# Altium schematic sheet 06 AFE&ADC Power.SchDoc
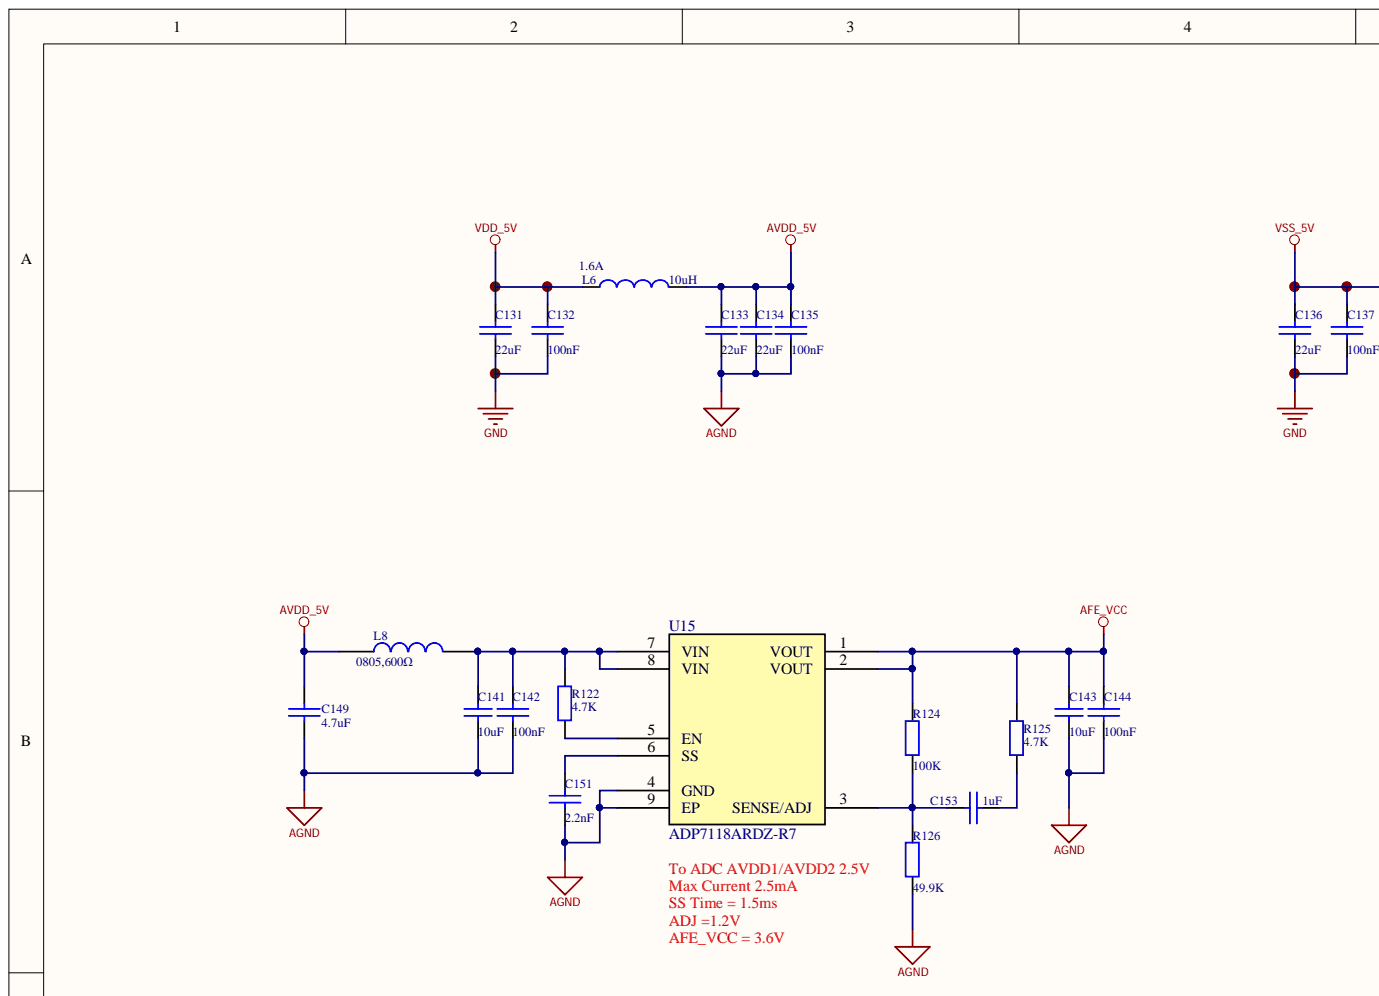
[diagram p0: 06 AFE&ADC Power.SchDoc, top left]
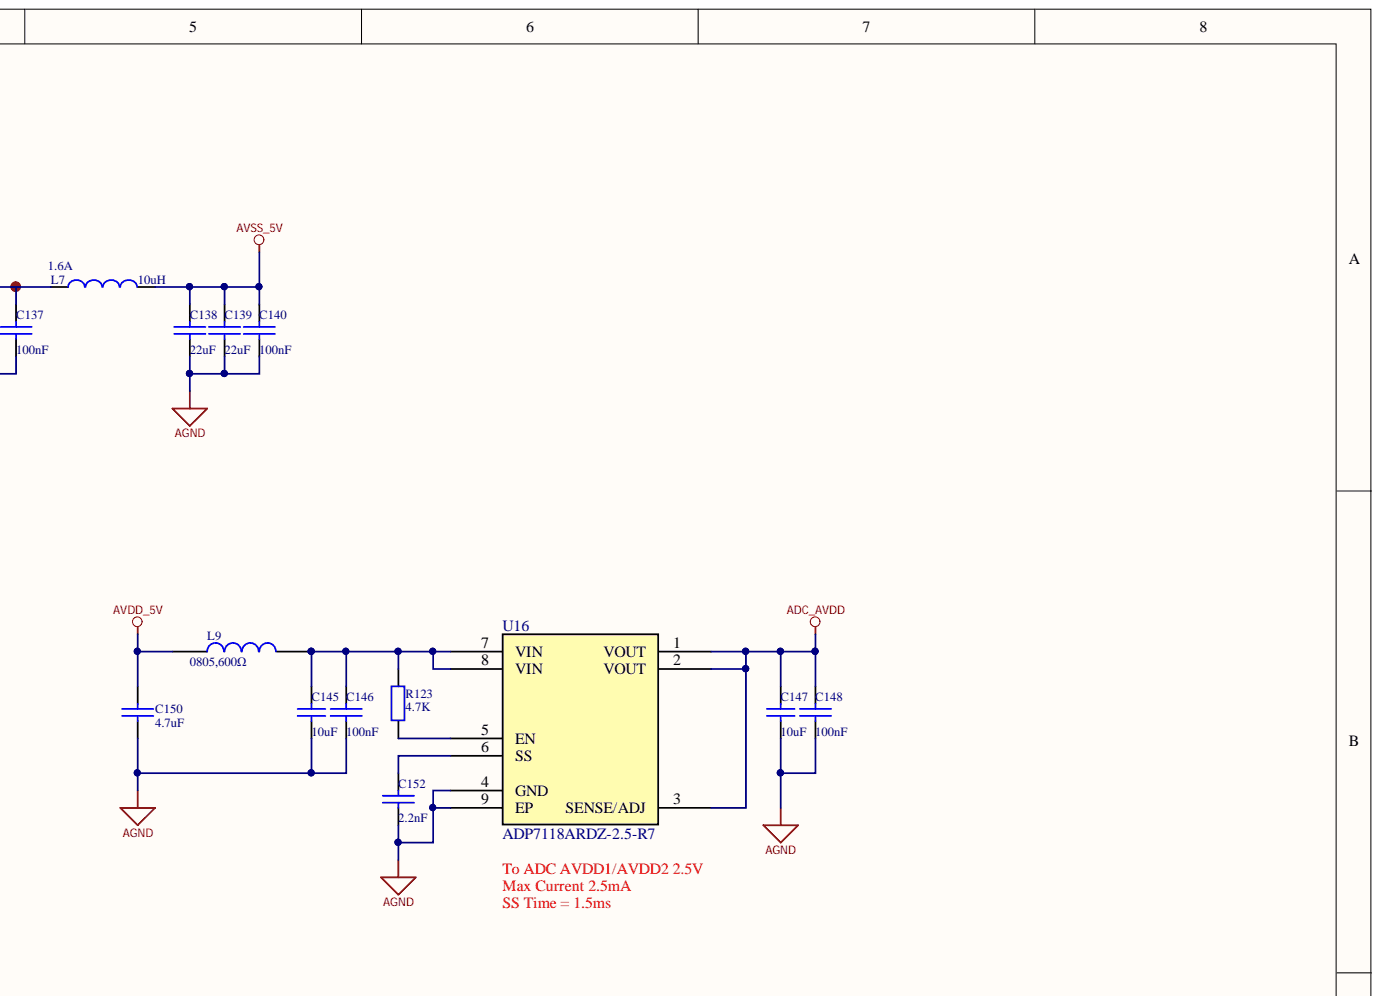
[diagram p1: 06 AFE&ADC Power.SchDoc, top right]
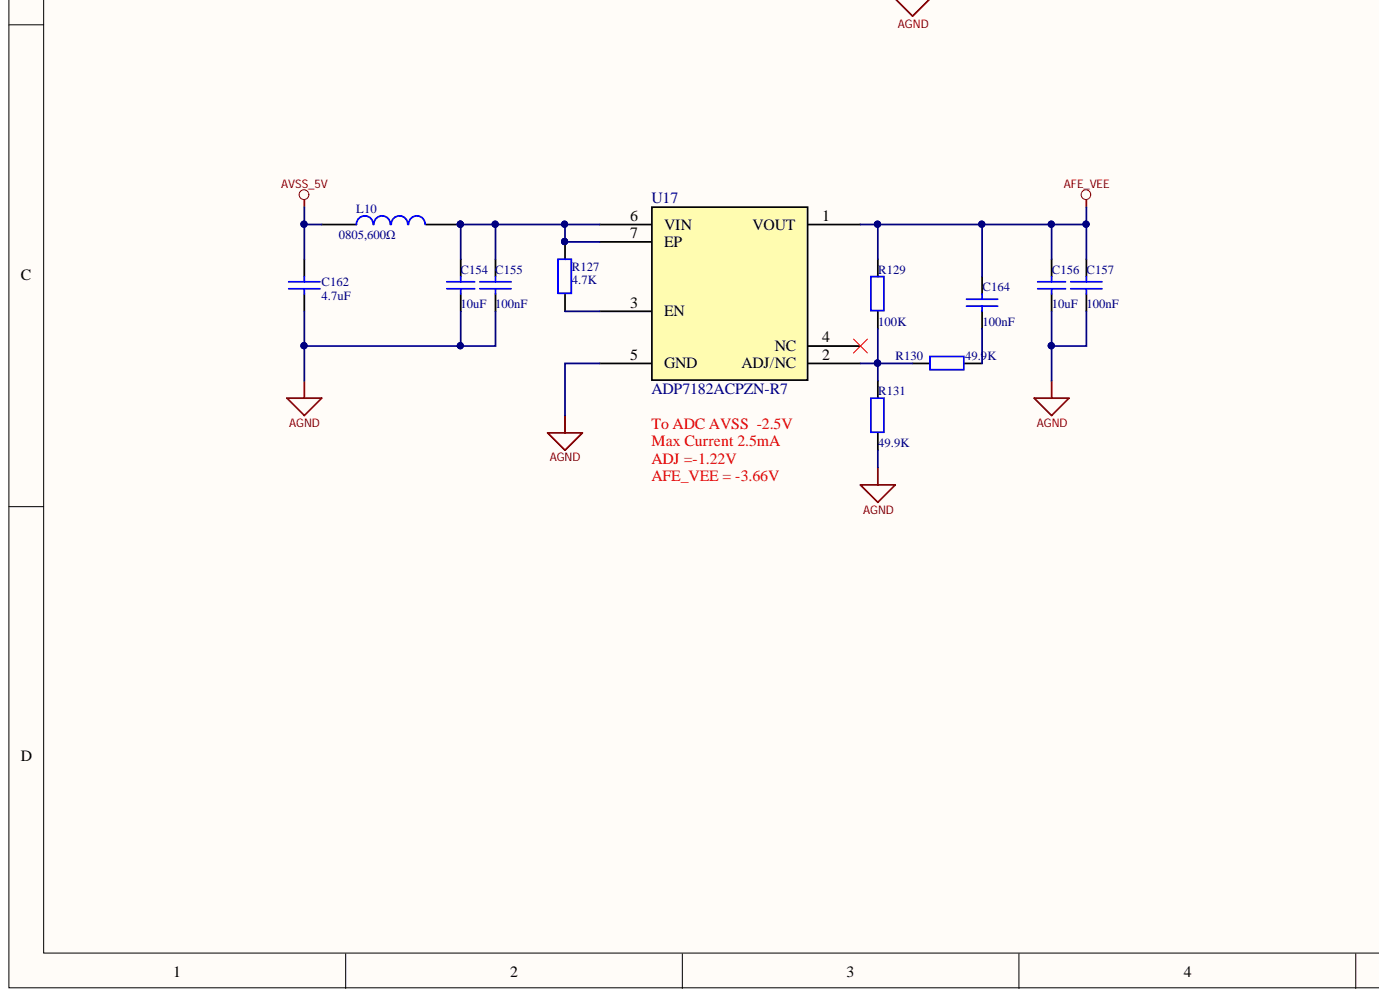
[diagram p2: 06 AFE&ADC Power.SchDoc, bottom left]
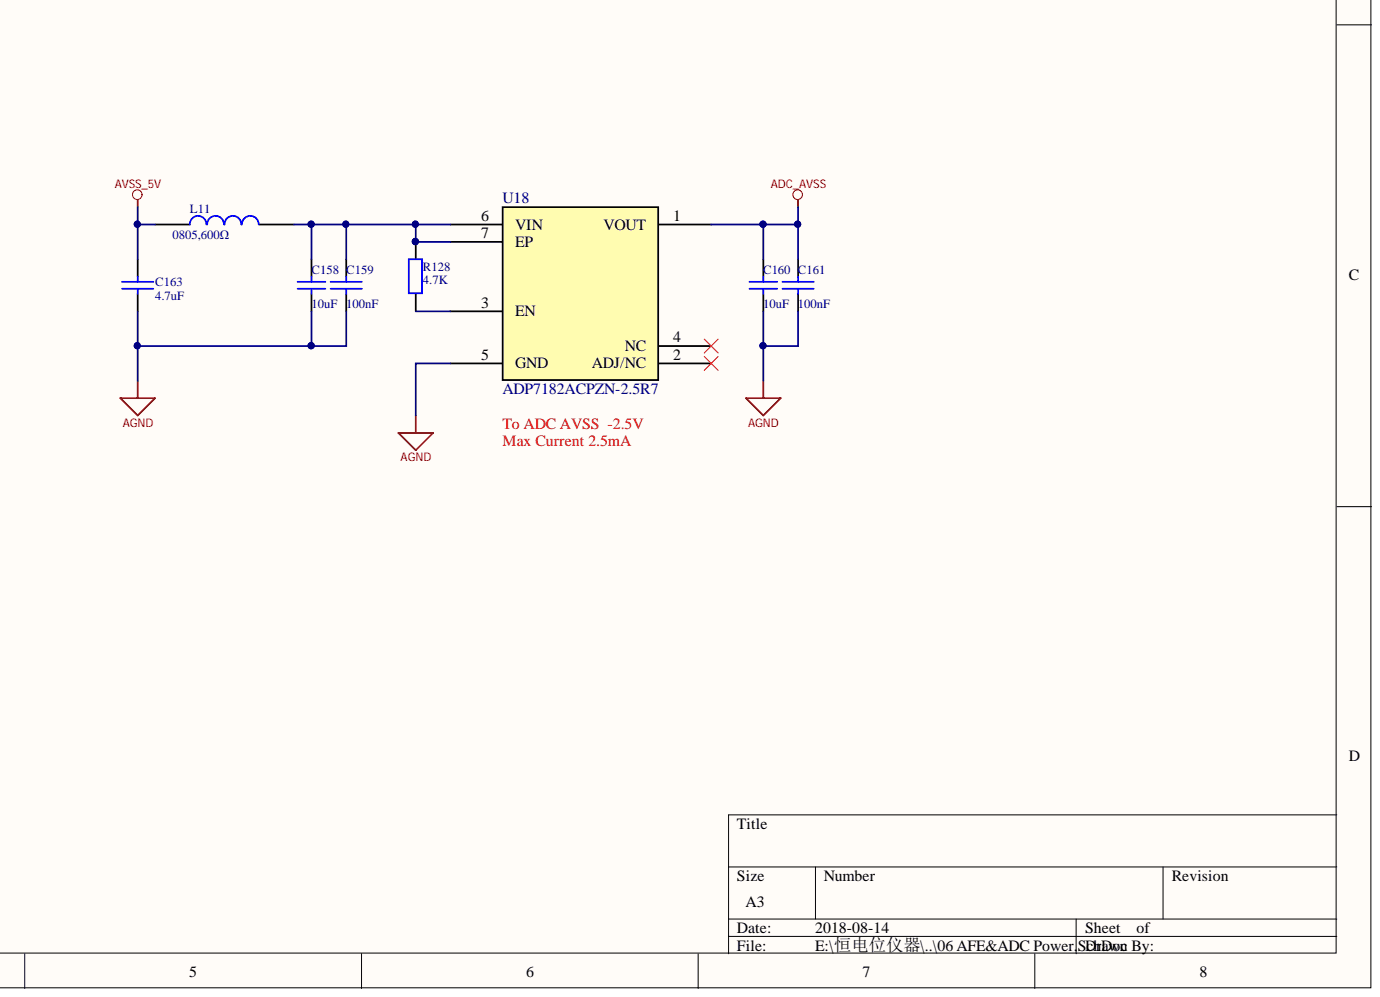
[diagram p3: 06 AFE&ADC Power.SchDoc, bottom right]

## Parts
C131  22uF  [SC0603]
C132  100nF  [SC0402]
C133  22uF  [SC0603]
C134  22uF  [SC0603]
C135  100nF  [SC0402]
C136  22uF  [SC0603]
C137  100nF  [SC0402]
C138  22uF  [SC0603]
C139  22uF  [SC0603]
C140  100nF  [SC0402]
C141  10uF  [SC0805]
C142  100nF  [SC0603]
C143  10uF  [SC0805]
C144  100nF  [SC0402]
C145  10uF  [SC0805]
C146  100nF  [SC0603]
C147  10uF  [SC0805]
C148  100nF  [SC0402]
C149  4.7uF  [SC0603]
C150  4.7uF  [SC0603]
C151  2.2nF  [SC0603]
C152  2.2nF  [SC0603]
C153  1uF  [SC0402]
C154  10uF  [SC0805]
C155  100nF  [SC0603]
C156  10uF  [SC0805]
C157  100nF  [SC0402]
C158  10uF  [SC0805]
C159  100nF  [SC0603]
C160  10uF  [SC0805]
C161  100nF  [SC0402]
C162  4.7uF  [SC0603]
C163  4.7uF  [SC0603]
C164  100nF  [SC0402]
L6  value 10uH  Saturation Current: 1.6A  [HSL4020]  (hidden comment: 终端片式电感-10uH-+/-20%-0.9A-0.215OHM-4.0*2.0)
L7  value 10uH  Saturation Current: 1.6A  [HSL4020]  (hidden comment: 终端片式电感-10uH-+/-20%-0.9A-0.215OHM-4.0*2.0)
L8  0805,600Ω  [SL0805]
L9  0805,600Ω  [SL0805]
L10  0805,600Ω  [SL0805]
L11  0805,600Ω  [SL0805]
R122  value 4.7K  [SR0402]  (hidden comment: 4.7K±1%)
R123  value 4.7K  [SR0402]  (hidden comment: 4.7K±1%)
R124  value 100K  [SR0402]  (hidden comment: 100K±1%)
R125  value 4.7K  [SR0402]  (hidden comment: 4.7K±1%)
R126  value 49.9K  [SR0402]  (hidden comment: 49.9K±1%)
R127  value 4.7K  [SR0402]  (hidden comment: 4.7K±1%)
R128  value 4.7K  [SR0402]  (hidden comment: 4.7K±1%)
R129  value 100K  [SR0402]  (hidden comment: 100K±1%)
R130  49.9K±1%  value 49.9K  [SR0402]
R131  value 49.9K  [SR0402]  (hidden comment: 49.9K±1%)
U15  ADP7118ARDZ-R7  [RD_8_1]
U16  ADP7118ARDZ-2.5-R7  [RD_8_1]
U17  ADP7182ACPZN-R7  [CP_6_3]
U18  ADP7182ACPZN-2.5R7  [CP_6_3]

## Nets
ADC_AVDD: C147.1 C148.2 U16.1(VOUT) U16.2(VOUT) U16.3(SENSE/ADJ)
ADC_AVSS: C160.1 C161.2 U18.1(VOUT)
AFE_VCC: C143.1 C144.2 R124.2 R125.2 U15.1(VOUT) U15.2(VOUT)
AFE_VEE: C156.1 C157.2 C164.1 R129.2 U17.1(VOUT)
AGND: C133.1 C134.1 C135.1 C138.1 C139.1 C140.1 C141.2 C142.2 C143.2 C144.1 C145.2 C146.2 C147.2 C148.1 C149.2 C150.2 C151.1 C152.1 C154.2 C155.2 C156.2 C157.1 C158.2 C159.2 C160.2 C161.1 C162.2 C163.2 R126.1 R131.1 U15.4(GND) U15.9(EP) U16.4(GND) U16.9(EP) U17.5(GND) U18.5(GND)
AVDD_5V: C133.2 C134.2 C135.2 C149.1 C150.1 L6.2 L8.1 L9.1
AVSS_5V: C138.2 C139.2 C140.2 C162.1 C163.1 L7.2 L10.1 L11.1
GND: C131.1 C132.1 C136.1 C137.1
VDD_5V: C131.2 C132.2 L6.1
VSS_5V: C136.2 C137.2 L7.1
NetC141_1: C141.1 C142.1 L8.2 R122.2 U15.7(VIN) U15.8(VIN)
NetC145_1: C145.1 C146.1 L9.2 R123.2 U16.7(VIN) U16.8(VIN)
NetC151_2: C151.2 U15.6(SS)
NetC152_2: C152.2 U16.6(SS)
NetC153_1: C153.1 R125.1
NetC153_2: C153.2 R124.1 R126.2 U15.3(SENSE/ADJ)
NetC154_1: C154.1 C155.1 L10.2 R127.2 U17.6(VIN) U17.7(EP)
NetC158_1: C158.1 C159.1 L11.2 R128.2 U18.6(VIN) U18.7(EP)
NetC164_2: C164.2 R130.1
NetR122_1: R122.1 U15.5(EN)
NetR123_1: R123.1 U16.5(EN)
NetR127_1: R127.1 U17.3(EN)
NetR128_1: R128.1 U18.3(EN)
NetR129_1: R129.1 R130.2 R131.2 U17.2(ADJ/NC)

Not connected: U17 4(NC); U18 2(ADJ/NC) 4(NC)

## Library data of the parts
C131 C133 C134 C136 C138 C139 = CAP: Capacitor; Part_Comments: SMD陶瓷电容-10V-22uF-+/-20%-X5R-0603; COMPONENT_HIGH_MAX: 0.9MM; DEVICE: CC0603MRX5R6BB226; Vendor: Yageo; Voltage: 10V; Component Kind: Standard; Value: 22uF
C132 C135 C137 C140 C144 C148 C157 C161 C164 = CAP: Capacitor; Part_Comments: SMD陶瓷电容-10V-100nF-+/-10%-X7R-040; Code: 101024500028; COMPONENT_HIGH_MAX: 0.55MM; DEVICE: CC0402KRX5R6BB104; Vendor: Yageo; Voltage: 10V; Component Kind: Standard; Value: 100nF
C141 C143 C145 C147 C154 C156 C158 C160 = Cap Semi: Capacitor; Part_Comments: SMD陶瓷电容-25V-10uF-+/-10%-X5R-0805; Code: 101024500042; DEVICE: CC0805KKX5R8BB106; Vendor: Yageo; Voltage: 25V; Component Kind: Standard; Library Name: Miscellaneous Devices.IntLib; Signal Integrity: Cap Semi; Simulation: CAP; Value: 10uF
C142 C146 C155 C159 = CAP: Capacitor; Part_Comments: SMD陶瓷电容-50V-100nF-+/-10%-X7R-0603; COMPONENT_HIGH_MAX: 0.55MM; DEVICE: CC0603KRX7R9BB104; Vendor: Yageo; Voltage: 50V; Component Kind: Standard; Value: 100nF
C149 C150 C162 C163 = CAP: Capacitor; Part_Comments: SMD陶瓷电容-16V-4.7uF-+/-10%-X5R-0603; DEVICE: CC0603KRX5R7BB475; Vendor: Yageo; Voltage: 16V; Component Kind: Standard; Value: 4.7uF
C151 C152 = CAP: Capacitor; Part_Comments: SMD陶瓷电容-50V-2.2nF-+/-10%-X7R-0603; COMPONENT_HIGH_MAX: 0.55MM; DEVICE: CC0603KRX7R9BB102; Vendor: Yageo; Voltage: 50V; Component Kind: Standard; Value: 2.2nF
C153 = CAP: Capacitor; Part_Comments: SMD陶瓷电容-10V-1uF-+/-10%-X5R-0402; Code: 101024500093; COMPONENT_HIGH_MAX: 0.55MM; DEVICE: CC0402KRX5R6BB105; Vendor: Yageo; Voltage: 10V; Component Kind: Standard; Value: 1uF
L6 L7 = INDUCTOR1: SDRH127H-4R7MC-12A; DEVICE: SWPA4020S100MT; Irms@40°C rise: 0.9A; Vendor: Sunlord; Component Kind: Standard; Part_Comments: 终端片式电感-10uH-+/-20%-0.9A-0.215OHM-4.0*2.0
L8-L11 = INDUCTOR: 贴片磁珠频率-600Ω-±25%-0.25Ω-1.5A-0805; Code: 101037512601; Device: PZ2012U601; Value: PZ2012U601; Vendor: Sunlord; Component Kind: Standard; Part_Comments: 贴片磁珠频率-600Ω-±25%-0.25Ω-1.5A-0805
R122 R123 R125 R127 R128 = Res2: Resistor; Part_Comments: 片式厚膜电阻器-0.0625W-4.7KOHM-+/-1%-0402; Code: 101017400060; DEVICE: RC0402FR-074K7L; Vendor: Yageo; Component Kind: Standard; Library Name: Miscellaneous Devices.IntLib; Signal Integrity: Res; Simulation: RESISTOR
R124 R129 = Res2: Resistor; Part_Comments: 片式厚膜电阻器-0.0625W-100KOHM-+/-1%-0402; DEVICE: RC0402FR-07100KL; Vendor: Yageo; Component Kind: Standard; Library Name: Miscellaneous Devices.IntLib
R126 R130 R131 = Res2: Resistor; Part_Comments: 片式厚膜电阻器-0.0625W-49.9KOHM-0402; Code: 101017400160; DEVICE: RC0402FR-0749K9L; Vendor: Yageo; Component Kind: Standard; Library Name: Miscellaneous Devices.IntLib; Signal Integrity: Res; Simulation: RESISTOR
U15 U16 = ADP7118ARDZ: 20V,200 mA,Low Noise,CMOS LDO Linear Regulator; Vendor: ADI; Component Kind: Standard; Library Name: Potentiostat.SchLib
  U15: Value: ADP7118ARDZ-R7
  U16: Value: ADP7118ARDZ-2.5-R7
U17 = ADP7182ACPZN-R7: –28 V, −200 mA, Low Noise, Linear Regulator; Value: ADP7182ACPZN-2.5R7; Vendor: ADI; Component Kind: Standard; Library Name: Potentiostat.SchLib
U18 = ADP7182ACPZN-R7: –28 V, −200 mA, Low Noise, Linear Regulator; Vendor: ADI; Component Kind: Standard; Value: ADP7182ACPZN-2.5R7; Library Name: Potentiostat.SchLib
